# Altium schematic sheet WireBoard.SchDoc(WireBoard)
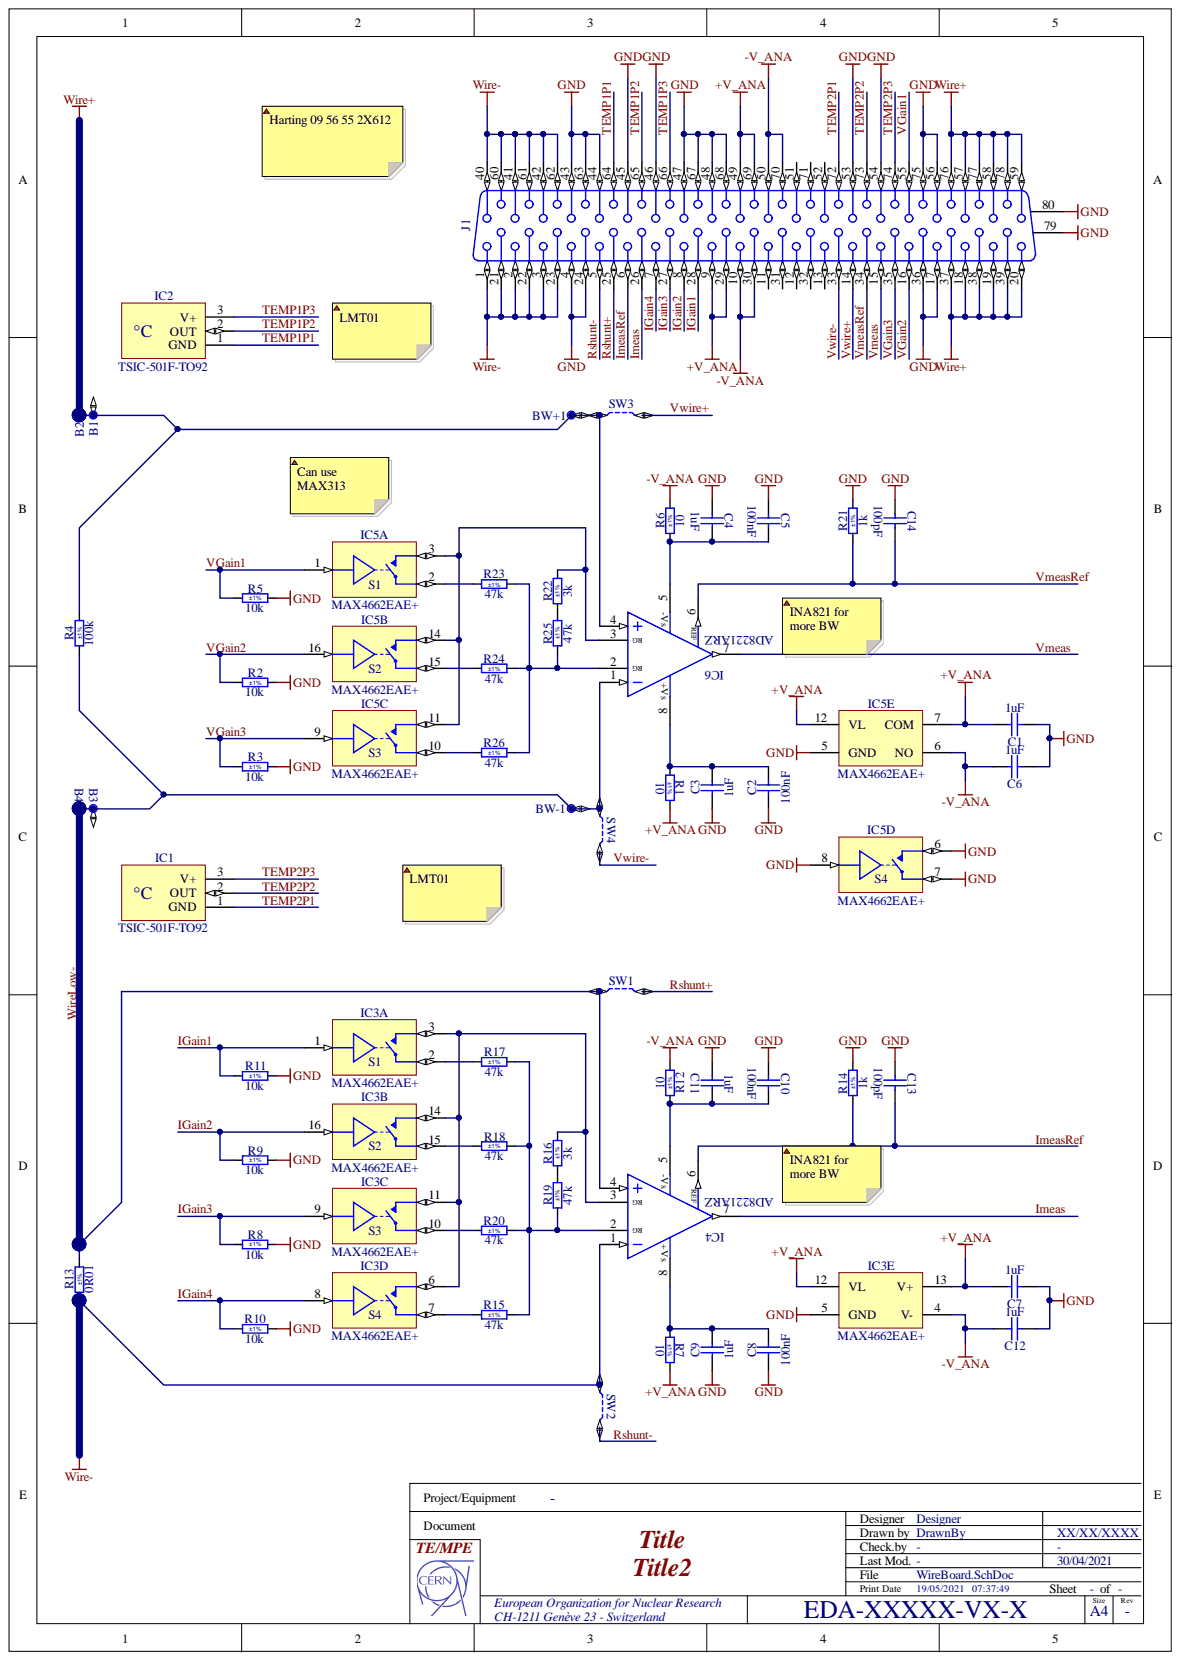
[diagram p0: WireBoard.SchDoc]

## Parts
B1  Plated Hole  [MTG320_800]  (value not drawn)  (no BOM)
B2  Plated Hole  [MTG320_800]  (value not drawn)  (no BOM)
B3  Plated Hole  [MTG320_800]  (value not drawn)  (no BOM)
B4  Plated Hole  [MTG320_800]  (value not drawn)  (no BOM)
BW+1  Plated Hole  [c160h110]  (value not drawn)  (no BOM)
BW-1  Plated Hole  [c160h110]  (value not drawn)  (no BOM)
C1  1uF  [CAPC1608X87N]
C2  100nF  [CAPC1608X87N]
C3  1uF  [CAPC1608X87N]
C4  1uF  [CAPC1608X87N]
C5  100nF  [CAPC1608X87N]
C6  1uF  [CAPC1608X87N]
C7  1uF  [CAPC1608X87N]
C8  100nF  [CAPC1608X87N]
C9  1uF  [CAPC1608X87N]
C10  100nF  [CAPC1608X87N]
C11  1uF  [CAPC1608X87N]
C12  1uF  [CAPC1608X87N]
C13  100pF  [CAPC1608X87N]
C14  100pF  [CAPC1608X87N]
IC1  TSIC-501F-TO92  [IST_TO-92]
IC2  TSIC-501F-TO92  [IST_TO-92]
IC3  MAX4662EAE+  [SOP65P778X199-16N]  (parts A,B,C,D,E)
IC4  AD8221ARZ  [SOIC127P600X175-8N]
IC5  MAX4662EAE+  [SOP65P778X199-16N]  (parts A,B,C,D,E)
IC6  AD8221ARZ  [SOIC127P600X175-8N]
J1  D-Sub 78F  [HARTING_09 56 552 7613]  (value not drawn)
R1  10  [RESC1608X55N]
R2  10k  Tolerance: ±1%  [RESC1608X55N]
R3  10k  Tolerance: ±1%  [RESC1608X55N]
R4  100k  Tolerance: ±1%  [RESC2012X60N]
R5  10k  Tolerance: ±1%  [RESC1608X55N]
R6  10  Tolerance: ±1%  [RESC1608X55N]
R7  10  Tolerance: ±1%  [RESC1608X55N]
R8  10k  Tolerance: ±1%  [RESC1608X55N]
R9  10k  Tolerance: ±1%  [RESC1608X55N]
R10  10k  Tolerance: ±1%  [RESC1608X55N]
R11  10k  Tolerance: ±1%  [RESC1608X55N]
R12  10  Tolerance: ±1%  [RESC1608X55N]
R13  0R01  Tolerance: ±1%  [RESC_VISHAY_WSL2512_0R007-0R5]
R14  1k  Tolerance: ±1%  [RESC1608X55N]
R15  47k  Tolerance: ±1%  [RESC2012X60N]
R16  3k  Tolerance: ±1%  [RESC2012X60N]
R17  47k  Tolerance: ±1%  [RESC2012X60N]
R18  47k  Tolerance: ±1%  [RESC2012X60N]
R19  47k  Tolerance: ±1%  [RESC2012X60N]
R20  47k  Tolerance: ±1%  [RESC2012X60N]
R21  1k  Tolerance: ±1%  [RESC1608X55N]
R22  3k  [RESC2012X60N]
R23  47k  Tolerance: ±1%  [RESC2012X60N]
R24  47k  Tolerance: ±1%  [RESC2012X60N]
R25  47k  Tolerance: ±1%  [RESC2012X60N]
R26  47k  Tolerance: ±1%  [RESC2012X60N]
SW1  Switch  [JUMPSMD0805_G50]  (value not drawn)  (no BOM)
SW2  Switch  [JUMPSMD0805_G50]  (value not drawn)  (no BOM)
SW3  Switch  [JUMPSMD0805_G50]  (value not drawn)  (no BOM)
SW4  Switch  [JUMPSMD0805_G50]  (value not drawn)  (no BOM)

## Nets
+V_ANA: C1.1 C7.1 IC3.12(VL) IC3.13(V+) IC5.7 IC5.12(VL) J1.9 J1.29 J1.49 J1.69 R1.2 R7.2
-V_ANA: C6.1 C12.1 IC3.4(V-) IC5.6 J1.10 J1.30 J1.50 J1.70 R6.2 R12.2
GND: C1.2 C2.1 C3.1 C4.1 C5.1 C6.2 C7.2 C8.1 C9.1 C10.1 C11.1 C12.2 C13.1 C14.1 IC3.5(GND) IC5.5(GND) IC5.6 IC5.7 IC5.8 J1.4 J1.17 J1.24 J1.36 J1.43 J1.44 J1.45 J1.46 J1.47 J1.48 J1.53 J1.54 J1.56 J1.63 J1.67 J1.68 J1.75 J1.79 J1.80 R2.1 R3.1 R5.1 R8.1 R9.1 R10.1 R11.1 R14.2 R21.2
Wire+: B1.1 B2.1 BW+1.1 IC6.4 J1.18 J1.19 J1.20 J1.37 J1.38 J1.39 J1.57 J1.58 J1.59 J1.76 J1.77 J1.78 R4.2 SW3.1
Wire-: IC4.1 J1.1 J1.2 J1.3 J1.21 J1.22 J1.23 J1.40 J1.41 J1.42 J1.60 J1.61 J1.62 R13.1 SW2.1
IGain1: IC3.1 J1.28 R11.2
IGain2: IC3.16 J1.8 R9.2
IGain3: IC3.9 J1.27 R8.2
IGain4: IC3.8 J1.7 R10.2
Imeas: IC4.7 J1.26
ImeasRef: C13.2 IC4.6 J1.6 R14.1
Rshunt+: J1.25 SW1.2
Rshunt-: J1.5 SW2.2
TEMP1P1: IC2.1 J1.64
TEMP1P2: IC2.2 J1.65
TEMP1P3: IC2.3 J1.66
TEMP2P1: IC1.1 J1.72
TEMP2P2: IC1.2 J1.73
TEMP2P3: IC1.3 J1.74
VGain1: IC5.1 J1.55 R5.2
VGain2: IC5.16 J1.16 R2.2
VGain3: IC5.9 J1.35 R3.2
Vmeas: IC6.7 J1.15
VmeasRef: C14.2 IC6.6 J1.34 R21.1
Vwire+: J1.14 SW3.2
Vwire-: J1.33 SW4.2
WireLow-: B3.1 B4.1 BW-1.1 IC4.4 IC6.1 R4.1 R13.2 SW1.1 SW4.1
NetC2_2: C2.2 C3.2 IC6.8 R1.1
NetC4_2: C4.2 C5.2 IC6.5 R6.1
NetC8_2: C8.2 C9.2 IC4.8 R7.1
NetC10_2: C10.2 C11.2 IC4.5 R12.1
NetIC3_2: IC3.2 R17.1
NetIC3_3: IC3.3 IC3.6 IC3.11 IC3.14 IC4.3(RG) R16.2
NetIC3_7: IC3.7 R15.1
NetIC3_10: IC3.10 R20.1
NetIC3_15: IC3.15 R18.1
NetIC4_2: IC4.2(RG) R15.2 R17.2 R18.2 R19.1 R20.2
NetIC5_2: IC5.2 R23.1
NetIC5_3: IC5.3 IC5.11 IC5.14 IC6.3(RG) R22.2
NetIC5_10: IC5.10 R26.1
NetIC5_15: IC5.15 R24.1
NetIC6_2: IC6.2(RG) R23.2 R24.2 R25.1 R26.2
NetR16_1: R16.1 R19.2
NetR22_1: R22.1 R25.2

Not connected: J1 11 12 13 31 32 51 52 71

## Library data of the parts
B1-B4 = PLATED_HOLE3.2_PAD8.0: Single Terminal Socket; Part Description: Plated Through Hole: Hole Dia.=3.2mm Pad Dia.=8.0mm; Mounted: No; Database Table Name: Pads; Status: None; Socket: No; SMD: No; Sense: No; Pin Count: 1; Part Number: PLATED_HOLE3.2_PAD8.0; PackageDescription: Plated Through Hole: Hole Dia.=3.2mm Pad Dia.=8.0mm; Library Name: Eletro-mechanical.DbLib; LatestRevisionDate: 28/11/2017; HelpURL: Undefined; Family: Plated Hole; CreateDate: 28/11/2017; Component Type: Standard (No BOM); ComponentHeight: 0mm; Author: CERN DEM JMW
BW+1 BW-1 = PLATED_HOLE1.1_PAD1.6: Single Terminal Socket; Part Description: Plated Through Hole: Hole Dia.=1.1mm Pad Dia.=1.6mm, IPC-7251 Level C; Mounted: No; Database Table Name: Pads; Status: None; Socket: No; SMD: No; Sense: No; Pin Count: 1; Part Number: PLATED_HOLE1.1_PAD1.6; PackageDescription: Plated Through Hole: Hole Dia.=1.1mm Pad Dia.=1.6mm, IPC-7251 Level C; Library Name: Eletro-mechanical.DbLib; LatestRevisionDate: 30/10/2008; HelpURL: Undefined; Family: Plated Hole; CreateDate: 30/10/2008; Component Type: Standard (No BOM); ComponentHeight: 0mm; Author: CERN DEM JLC
C1 C3 C4 C6 C7 C9 C11 C12 = CC0603_1UF_16V_10%_X7R: Non-polarised capacitor; Part Description: SMD Multilayer Chip Ceramic Capacitor; Manufacturer1 Part Number: 223878615663; Manufacturer: GENERIC; Voltage: 16V; Tolerance: ±10%; TC: X7R; Database Table Name: Capacitors SMD; Value: 1uF; Status: None; Socket: No; SMD: Yes; Sense: No; Pin Count: 2; Part Number: CC0603_1UF_16V_10%_X7R; Mounted: Yes; Manufacturer Part Number: CC0603_1UF_16V_10%_X7R; Manufacturer1 Example: YAGEO PHYCOMP; Manufacturer1 ComponentHeight: 0.9mm; Library Name: Capacitors.DbLib; LatestRevisionDate: 17/04/2015; HelpURL: \\cern.ch\dfs\Applications\Altium\Datasheets\CC0603_X7R_PHYCOMP.pdf; CreateDate: 03/12/2007; Component Type: Standard; Component Kind: Standard; Case: 0603; Author: CERN DEM JLC
C2 C5 C8 C10 = CC0603_100NF_50V_10%_X7R: Non-polarised capacitor; Part Description: SMD Multilayer Chip Ceramic Capacitor; Manufacturer1 Part Number: 223858615649; Manufacturer: GENERIC; Voltage: 50V; Tolerance: ±10%; TC: X7R; Database Table Name: Capacitors SMD; Value: 100nF; Status: Preferred; Socket: No; SMD: Yes; Sense: No; Pin Count: 2; Part Number: CC0603_100NF_50V_10%_X7R; Mounted: Yes; Manufacturer Part Number: CC0603_100NF_50V_10%_X7R; Manufacturer1 Example: PHYCOMP; Manufacturer1 ComponentHeight: 0.87mm; Library Name: Capacitors.DbLib; LatestRevisionDate: 03/12/2007; HelpURL: \\cern.ch\dfs\Applications\Altium\Datasheets\CC0603_X7R_PHYCOMP.pdf; CreateDate: 03/12/2007; Component Type: Standard; Component Kind: Standard; Case: 0603; Author: CERN DEM JLC
C13 C14 = CC0603_100PF_50V_10%_X7R: Non-polarised capacitor; Part Description: SMD Multilayer Chip Ceramic Capacitor; Manufacturer1 Part Number: 223858615609; Manufacturer: GENERIC; Voltage: 50V; Tolerance: ±10%; TC: X7R; Database Table Name: Capacitors SMD; Value: 100pF; Status: Preferred; Socket: No; SMD: Yes; Sense: No; Pin Count: 2; Part Number: CC0603_100PF_50V_10%_X7R; Mounted: Yes; Manufacturer Part Number: CC0603_100PF_50V_10%_X7R; Manufacturer1 Example: PHYCOMP; Manufacturer1 ComponentHeight: 0.9mm; Library Name: Capacitors.DbLib; LatestRevisionDate: 15/08/2012; HelpURL: \\cern.ch\dfs\Applications\Altium\Datasheets\CC0603_X7R_PHYCOMP.pdf; CreateDate: 15/08/2012; Component Type: Standard; Component Kind: Standard; Case: 0603; Author: CERN DEM JLC
IC1 IC2 = TSIC-501F-TO92: High Precision, Longterm Stable Temperature Sensor IC; Part Description: -10°C to +60°C, High Precision (±0.1°C), Longterm Stable Temperature Sensor IC; Manufacturer: IST (INNOVATIVE SENSOR TECHNOLOGY); PressFit: No; Database Table Name: Sensors; Bonding: No; Socket: No; SMD: No; Sense: No; Pin Count: 3; Part Number: TSIC-501F-TO92; PackageDescription: TO-92, Pitch 2.54mm, Flat Index, 3 In-Line, Bent Leads, Body 4.57 x 2.285mm; Mounted: Yes; Manufacturer Part Number: TSIC-501F-TO92; Library Name: ICs And Semiconductors.DbLib; LatestRevisionDate: 22/07/2016; HelpURL: \\cern.ch\dfs\Applications\Altium\Datasheets\TSIC-501F-TO92.pdf; Device: TSIC-501F-TO92; CreateDate: 24/09/2014; Component Type: Standard; Component Kind: Standard; ComponentHeight: 8mm; Case: TO-92; Author: CERN DEM JLC
IC3 IC5 = MAX4662EAE+: 2.5 Ohm, Quad, SPST, CMOS Analog Switches (Normally Open); Manufacturer: MAXIM; PressFit: No; Database Table Name: Analog & Interface; Bonding: No; Socket: No; SMD: Yes; Sense: No; Pin Count: 16; Part Number: MAX4662EAE+; Part Description: 2.5 Ohm, Quad, SPST, CMOS Analog Switches (Normally Open); PackageDescription: SOP, 0.65mm Pitch; 16 Pin, 5.29mm W X 6.20mm L X 1.99mm H body, IPC Medium Density; Mounted: Yes; Manufacturer Part Number: MAX4662EAE+; Library Name: ICs And Semiconductors.DbLib; LatestRevisionDate: 15/11/2018; HelpURL: \\cern.ch\dfs\Applications\Altium\Datasheets\MAX4662EAE+.pdf; Device: MAX4662EAE+; CreateDate: 15/11/2018; Component Type: Standard; Component Kind: Standard; ComponentHeight: 1.99mm; Case: SSOP16; Author: CERN DEM JLC
IC4 IC6 = AD8221ARZ: Precision Instrumentation Amplifier; Manufacturer: ANALOG DEVICES; PressFit: No; Database Table Name: Operational Amplifiers; Bonding: No; Socket: No; SMD: Yes; Sense: No; Pin Count: 8; Part Number: AD8221ARZ; Part Description: Precision Instrumentation Amplifier; PackageDescription: SOIC 8, Pitch 1.27mm - Body 4x5mm, IPC Medium Density; Mounted: Yes; Manufacturer Part Number: AD8221ARZ; Library Name: ICs And Semiconductors.DbLib; LatestRevisionDate: 16/12/2009; HelpURL: \\cern.ch\dfs\Applications\Altium\Datasheets\AD8221ARZ.pdf; Device: AD8221ARZ; CreateDate: 16/12/2009; Component Type: Standard; Component Kind: Standard; ComponentHeight: 1.75mm; Case: SOIC8; Author: CERN DEM JLC
J1 = HARTING_09 56 552 7613: Connector D-sub 78 Female; Part Description: High Density Right Angle Female D-SUB 78 Contacts, with Grounding Board Locks and Female Screw Lock 4-40; PressFit: No; System: Connector; Status: None; Socket: No; SMD: No; Sense: No; Pin Count: 80; Part Number: HARTING_09 56 552 7613; Mounted: Yes; Manufacturer Part Number: 09 56 552 7613; Manufacturer: HARTING; Library Name: Connectors.DbLib; LatestRevisionDate: 11/12/2014; HelpURL: \\cern.ch\dfs\Applications\Altium\Datasheets\HARTING_09 56 552 7613.pdf; Family: D-Sub 78F; Database Table Name: HARTING; CreateDate: 11/12/2014; Component Type: Standard; Component Kind: Standard; ComponentHeight: 15.35mm; Author: CERN DEM JLC
R1 R6 R7 R12 = R0603_10R_1%_0.1W_200PPM: Resistor - 1%; Part Description: General Purpose Thick Film Chip Resistor; Manufacturer1 Part Number: NRC06F10R0TRF; Manufacturer: GENERIC; TC: ±200ppm/°C; PressFit: No; Power: 0.1W; Database Table Name: Resistors SMD; Value: 10; Status: Preferred; Socket: No; SMD: Yes; Sense: No; Pin Count: 2; Part Number: R0603_10R_1%_0.1W_200PPM; Mounted: Yes; Manufacturer Part Number: R0603_10R_1%_0.1W_200PPM; Manufacturer1 Example: NIC COMPONENT; Manufacturer1 ComponentHeight: 0.55mm; Library Name: Resistors.DbLib; LatestRevisionDate: 24/06/2009; HelpURL: \\cern.ch\dfs\Applications\Altium\Datasheets\R0603_NIC_NRC.pdf; CreateDate: 03/12/2007; Component Type: Standard; Component Kind: Standard; Case: 0603; Author: CERN DEM JLC
  R1: Tolerance: ±1%
R2 R3 R5 R8-R11 = R0603_10K_1%_0.1W_100PPM: Resistor - 1%; Part Description: General Purpose Thick Film Chip Resistor; Manufacturer1 Part Number: NRC06F1002TRF; Manufacturer: GENERIC; TC: ±100ppm/°C; PressFit: No; Power: 0.1W; Database Table Name: Resistors SMD; Value: 10k; Status: Preferred; Socket: No; SMD: Yes; Sense: No; Pin Count: 2; Part Number: R0603_10K_1%_0.1W_100PPM; Mounted: Yes; Manufacturer Part Number: R0603_10K_1%_0.1W_100PPM; Manufacturer1 Example: NIC COMPONENT; Manufacturer1 ComponentHeight: 0.55mm; Library Name: Resistors.DbLib; LatestRevisionDate: 13/03/2008; HelpURL: \\cern.ch\dfs\Applications\Altium\Datasheets\R0603_NIC_NRC.pdf; CreateDate: 03/12/2007; Component Type: Standard; Component Kind: Standard; Case: 0603; Author: CERN DEM JLC
R4 = R0805_100K_1%_0.125W_100PPM: Resistor - 1%; Part Description: General Purpose Thick Film Chip Resistor; Manufacturer1 Part Number: NRC10F1003TRF; Manufacturer: GENERIC; TC: ±100ppm/°C; PressFit: No; Power: 0.125W; Database Table Name: Resistors SMD; Value: 100k; Status: Preferred; Socket: No; SMD: Yes; Sense: No; Pin Count: 2; Part Number: R0805_100K_1%_0.125W_100PPM; Mounted: Yes; Manufacturer Part Number: R0805_100K_1%_0.125W_100PPM; Manufacturer1 Example: NIC COMPONENT; Manufacturer1 ComponentHeight: 0.6mm; Library Name: Resistors.DbLib; LatestRevisionDate: 13/03/2008; HelpURL: \\cern.ch\dfs\Applications\Altium\Datasheets\R0805_NIC_NRC.pdf; CreateDate: 03/12/2007; Component Type: Standard; Component Kind: Standard; Case: 0805; Author: CERN DEM JLC
R13 = R2512_0R01_1%_1W_75PPM_VISHAY_WSL: Resistor - 1%; Part Description: Power Metal Strip® Resistor; Manufacturer Part Number: WSL2512R0100FEA; Manufacturer: VISHAY DALE; TC: ±75ppm/°C; PressFit: No; Power: 1W; Database Table Name: Resistors SMD; Value: 0R01; Status: None; Socket: No; SMD: Yes; Sense: No; Pin Count: 2; Part Number: R2512_0R01_1%_1W_75PPM_VISHAY_WSL; Mounted: Yes; Library Name: Resistors.DbLib; LatestRevisionDate: 23/10/2017; HelpURL: \\cern.ch\dfs\Applications\Altium\Datasheets\R2512_VISHAY_WSL.pdf; CreateDate: 17/11/2011; Component Type: Standard; Component Kind: Standard; ComponentHeight: 0.89mm; Case: 2512; Author: CERN DEM JLC
R14 R21 = R0603_1K_1%_0.1W_100PPM: Resistor - 1%; Part Description: General Purpose Thick Film Chip Resistor; Manufacturer1 Part Number: NRC06F1001TRF; Manufacturer: GENERIC; TC: ±100ppm/°C; PressFit: No; Power: 0.1W; Database Table Name: Resistors SMD; Value: 1k; Status: Preferred; Socket: No; SMD: Yes; Sense: No; Pin Count: 2; Part Number: R0603_1K_1%_0.1W_100PPM; Mounted: Yes; Manufacturer Part Number: R0603_1K_1%_0.1W_100PPM; Manufacturer1 Example: NIC COMPONENT; Manufacturer1 ComponentHeight: 0.55mm; Library Name: Resistors.DbLib; LatestRevisionDate: 13/03/2008; HelpURL: \\cern.ch\dfs\Applications\Altium\Datasheets\R0603_NIC_NRC.pdf; CreateDate: 03/12/2007; Component Type: Standard; Component Kind: Standard; Case: 0603; Author: CERN DEM JLC
R15 R17-R20 R23-R26 = R0805_47K_1%_0.125W_100PPM: Resistor - 1%; Part Description: General Purpose Thick Film Chip Resistor; Manufacturer1 Part Number: NRC10F4702TRF; Manufacturer: GENERIC; TC: ±100ppm/°C; PressFit: No; Power: 0.125W; Database Table Name: Resistors SMD; Value: 47k; Status: Preferred; Socket: No; SMD: Yes; Sense: No; Pin Count: 2; Part Number: R0805_47K_1%_0.125W_100PPM; Mounted: Yes; Manufacturer Part Number: R0805_47K_1%_0.125W_100PPM; Manufacturer1 Example: NIC COMPONENT; Manufacturer1 ComponentHeight: 0.6mm; Library Name: Resistors.DbLib; LatestRevisionDate: 13/03/2008; HelpURL: \\cern.ch\dfs\Applications\Altium\Datasheets\R0805_NIC_NRC.pdf; CreateDate: 03/12/2007; Component Type: Standard; Component Kind: Standard; Case: 0805; Author: CERN DEM JLC
R16 R22 = R0805_3K_1%_0.125W_100PPM: Resistor - 1%; Part Description: General Purpose Thick Film Chip Resistor; Manufacturer1 Part Number: NRC10F3001TRF; Manufacturer: GENERIC; TC: ±100ppm/°C; PressFit: No; Power: 0.125W; Database Table Name: Resistors SMD; Value: 3k; Status: Preferred; Socket: No; SMD: Yes; Sense: No; Pin Count: 2; Part Number: R0805_3K_1%_0.125W_100PPM; Mounted: Yes; Manufacturer Part Number: R0805_3K_1%_0.125W_100PPM; Manufacturer1 Example: NIC COMPONENT; Manufacturer1 ComponentHeight: 0.6mm; Library Name: Resistors.DbLib; LatestRevisionDate: 13/03/2008; HelpURL: \\cern.ch\dfs\Applications\Altium\Datasheets\R0805_NIC_NRC.pdf; CreateDate: 03/12/2007; Component Type: Standard; Component Kind: Standard; Case: 0805; Author: CERN DEM JLC
  R22: Tolerance: ±1%
SW1-SW4 = JUMPSMD0805_G50: 2 SMD Pads for Bound by Solder  Drop; Part Description: 2 SMD Pads for Bound by Solder Drop - Equivalent Size 0805 - Gap 0.5mm; Manufacturer Part Number: None; PressFit: No; Mounted: No; Database Table Name: Switches; Status: None; Socket: No; SMD: No; Sense: No; Pin Count: 2; Part Number: JUMPSMD0805_G50; PackageDescription: 2 SMD Pads for Bound by Solder Drop - Equivalent Size 0805 - Gap 0.5mm; Manufacturer: None; Library Name: Eletro-mechanical.DbLib; LatestRevisionDate: 12/02/2021; HelpURL: None; Family: Switch; CreateDate: 26/08/2009; Component Type: Standard (No BOM); ComponentHeight: 0mm; Author: CERN DEM JLC
